# Altium schematic sheet Sheet1.SchDoc(Sheet1)
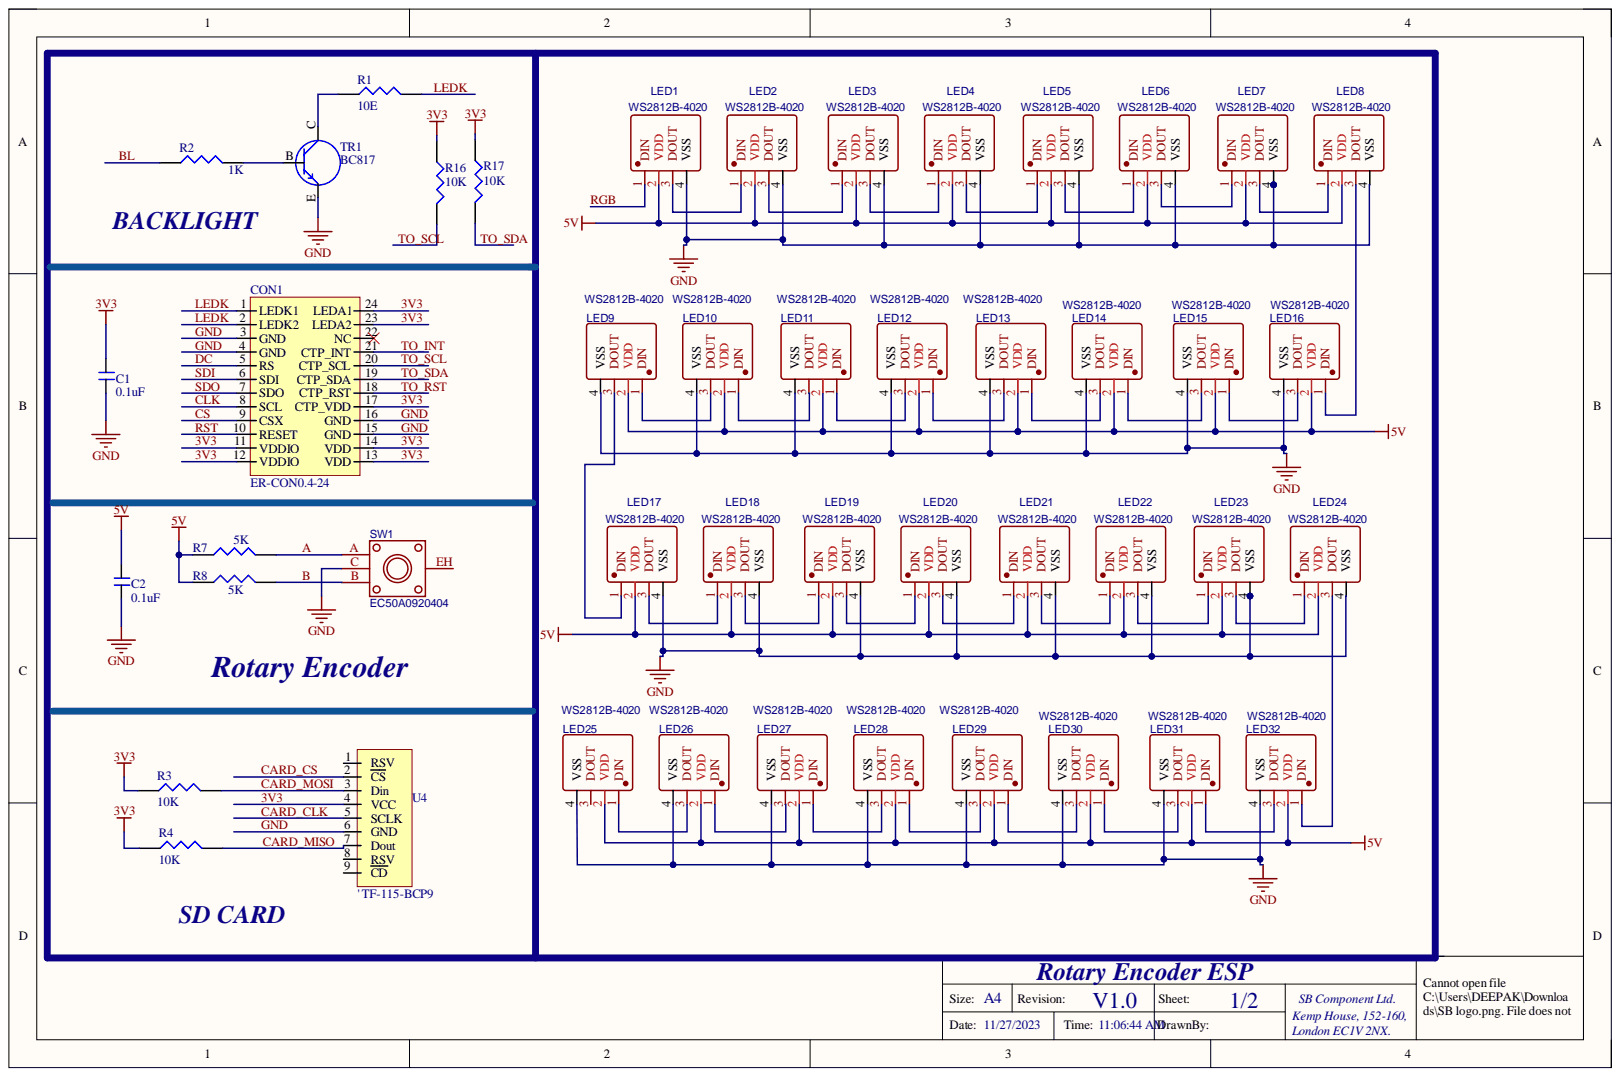
[diagram p0: Sheet1.SchDoc]

## Parts
C1  0.1uF  [C_0603]
C2  0.1uF  [C_0603]
CON1  ER-CON0.4-24  [1.28  TOUCH LCD CONN]
LED1  WS2812B-4020  [SIDE_RGB]
LED2  WS2812B-4020  [SIDE_RGB]
LED3  WS2812B-4020  [SIDE_RGB]
LED4  WS2812B-4020  [SIDE_RGB]
LED5  WS2812B-4020  [SIDE_RGB]
LED6  WS2812B-4020  [SIDE_RGB]
LED7  WS2812B-4020  [SIDE_RGB]
LED8  WS2812B-4020  [SIDE_RGB]
LED9  WS2812B-4020  [SIDE_RGB]
LED10  WS2812B-4020  [SIDE_RGB]
LED11  WS2812B-4020  [SIDE_RGB]
LED12  WS2812B-4020  [SIDE_RGB]
LED13  WS2812B-4020  [SIDE_RGB]
LED14  WS2812B-4020  [SIDE_RGB]
LED15  WS2812B-4020  [SIDE_RGB]
LED16  WS2812B-4020  [SIDE_RGB]
LED17  WS2812B-4020  [SIDE_RGB]
LED18  WS2812B-4020  [SIDE_RGB]
LED19  WS2812B-4020  [SIDE_RGB]
LED20  WS2812B-4020  [SIDE_RGB]
LED21  WS2812B-4020  [SIDE_RGB]
LED22  WS2812B-4020  [SIDE_RGB]
LED23  WS2812B-4020  [SIDE_RGB]
LED24  WS2812B-4020  [SIDE_RGB]
LED25  WS2812B-4020  [SIDE_RGB]
LED26  WS2812B-4020  [SIDE_RGB]
LED27  WS2812B-4020  [SIDE_RGB]
LED28  WS2812B-4020  [SIDE_RGB]
LED29  WS2812B-4020  [SIDE_RGB]
LED30  WS2812B-4020  [SIDE_RGB]
LED31  WS2812B-4020  [SIDE_RGB]
LED32  WS2812B-4020  [SIDE_RGB]
R1  10E  [R_0603]
R2  1K  [R_0603]
R3  10K  [R_0603]
R4  10K  [R_0603]
R7  5K  [R_0603]
R8  5K  [R_0603]
R16  10K  [R_0603]
R17  10K  [R_0603]
SW1  EC50A0920404  [Rotary Encoder 50mm]
TR1  BC817  [SOT23]
U4  ' TF-115-BCP9  [micro sd card]

## Nets
5V: C2.2 LED1.2(VDD) LED2.2(VDD) LED3.2(VDD) LED4.2(VDD) LED5.2(VDD) LED6.2(VDD) LED7.2(VDD) LED8.2(VDD) LED9.2(VDD) LED10.2(VDD) LED11.2(VDD) LED12.2(VDD) LED13.2(VDD) LED14.2(VDD) LED15.2(VDD) LED16.2(VDD) LED17.2(VDD) LED18.2(VDD) LED19.2(VDD) LED20.2(VDD) LED21.2(VDD) LED22.2(VDD) LED23.2(VDD) LED24.2(VDD) LED25.2(VDD) LED26.2(VDD) LED27.2(VDD) LED28.2(VDD) LED29.2(VDD) LED30.2(VDD) LED31.2(VDD) LED32.2(VDD) R7.1 R8.1
3V3: C1.2 CON1.11(VDDIO) CON1.12(VDDIO) CON1.13(VDD) CON1.14(VDD) CON1.17(CTP_VDD) CON1.23(LEDA2) CON1.24(LEDA1) R3.1 R4.1 R16.1 R17.1 U4.4(VCC)
A: R7.2 SW1.A
B: R8.2 SW1.B
BL: R2.1
CARD_CLK: U4.5(SCLK)
CARD_CS: U4.2(CS)
CARD_MISO: R4.2 U4.7(Dout)
CARD_MOSI: R3.2 U4.3(Din)
CLK: CON1.8(SCL)
CS: CON1.9(CSX)
DC: CON1.5(RS)
GND: C1.1 C2.1 CON1.3(GND) CON1.4(GND) CON1.15(GND) CON1.16(GND) LED1.4(VSS) LED2.4(VSS) LED3.4(VSS) LED4.4(VSS) LED5.4(VSS) LED6.4(VSS) LED7.4(VSS) LED8.4(VSS) LED9.4(VSS) LED10.4(VSS) LED11.4(VSS) LED12.4(VSS) LED13.4(VSS) LED14.4(VSS) LED15.4(VSS) LED16.4(VSS) LED17.4(VSS) LED18.4(VSS) LED19.4(VSS) LED20.4(VSS) LED21.4(VSS) LED22.4(VSS) LED23.4(VSS) LED24.4(VSS) LED25.4(VSS) LED26.4(VSS) LED27.4(VSS) LED28.4(VSS) LED29.4(VSS) LED30.4(VSS) LED31.4(VSS) LED32.4(VSS) SW1.C TR1.E U4.6(GND)
LEDK: CON1.1(LEDK1) CON1.2(LEDK2) R1.2
RGB: LED1.1(DIN)
RST: CON1.10(RESET)
SDI: CON1.6(SDI)
SDO: CON1.7(SDO)
TO_INT: CON1.21(CTP_INT)
TO_RST: CON1.18(CTP_RST)
TO_SCL: CON1.20(CTP_SCL) R16.2
TO_SDA: CON1.19(CTP_SDA) R17.2
NetLED1_3: LED1.3(DOUT) LED2.1(DIN)
NetLED2_3: LED2.3(DOUT) LED3.1(DIN)
NetLED3_3: LED3.3(DOUT) LED4.1(DIN)
NetLED4_3: LED4.3(DOUT) LED5.1(DIN)
NetLED5_3: LED5.3(DOUT) LED6.1(DIN)
NetLED6_3: LED6.3(DOUT) LED7.1(DIN)
NetLED7_3: LED7.3(DOUT) LED8.1(DIN)
NetLED8_3: LED8.3(DOUT) LED16.1(DIN)
NetLED9_1: LED9.1(DIN) LED10.3(DOUT)
NetLED9_3: LED9.3(DOUT) LED17.1(DIN)
NetLED10_1: LED10.1(DIN) LED11.3(DOUT)
NetLED11_1: LED11.1(DIN) LED12.3(DOUT)
NetLED12_1: LED12.1(DIN) LED13.3(DOUT)
NetLED13_1: LED13.1(DIN) LED14.3(DOUT)
NetLED14_1: LED14.1(DIN) LED15.3(DOUT)
NetLED15_1: LED15.1(DIN) LED16.3(DOUT)
NetLED17_3: LED17.3(DOUT) LED18.1(DIN)
NetLED18_3: LED18.3(DOUT) LED19.1(DIN)
NetLED19_3: LED19.3(DOUT) LED20.1(DIN)
NetLED20_3: LED20.3(DOUT) LED21.1(DIN)
NetLED21_3: LED21.3(DOUT) LED22.1(DIN)
NetLED22_3: LED22.3(DOUT) LED23.1(DIN)
NetLED23_3: LED23.3(DOUT) LED24.1(DIN)
NetLED24_3: LED24.3(DOUT) LED32.1(DIN)
NetLED25_1: LED25.1(DIN) LED26.3(DOUT)
NetLED26_1: LED26.1(DIN) LED27.3(DOUT)
NetLED27_1: LED27.1(DIN) LED28.3(DOUT)
NetLED28_1: LED28.1(DIN) LED29.3(DOUT)
NetLED29_1: LED29.1(DIN) LED30.3(DOUT)
NetLED30_1: LED30.1(DIN) LED31.3(DOUT)
NetLED31_1: LED31.1(DIN) LED32.3(DOUT)
NetR1_1: R1.1 TR1.C
NetR2_2: R2.2 TR1.B

Not connected: CON1 22(NC); LED25 3(DOUT); SW1 EH; U4 1(RSV) 8(RSV) 9(CD)

## Library data of the parts
C1 C2 = CAP_NONPOLAR: Component Kind: Standard; Library Name: dxp_pasl_lib.IntLib
LED1-LED32 = WS2812B-4020: BOM_Manufacturer: worldsemi; Contributor: LCSC; BOM_JLCPCB Part Class: Extended Part; BOM_Manufacturer Part: WS2812B-4020; BOM_Supplier: LCSC; BOM_Supplier Part: C965557; Component Kind: Standard; spicePre: L; spiceSymbolName: WS2812B-4020; Library Name: MIX.SchLib
R1-R4 R7 R8 R16 R17 = RES: Component Kind: Standard; Library Name: dxp_pasl_lib.IntLib
SW1 = Rotary Encoder: BOM_Manufacturer: ALPS Electric; Contributor: LCSC; BOM_JLCPCB Part Class: Extended Part; BOM_Manufacturer Part: EC50A0920404; BOM_Supplier: LCSC; BOM_Supplier Part: C470432; Component Kind: Standard; spicePre: S; spiceSymbolName: EC50A0920404; Library Name: MIX.SchLib
TR1 = TRANSISTER_NPN: Component Kind: Standard; Library Name: dxp_pasl_lib.IntLib
U4 = SD CARD TF: Micro SD Memory Card Socket; Component Kind: Standard; Library Name: dxp_pasl_lib.IntLib
CON1 = ER-CON0.4-24: Component Kind: Standard; Library Name: dxp_pasl_lib.IntLib
